# Altium schematic sheet POWER.SchDoc
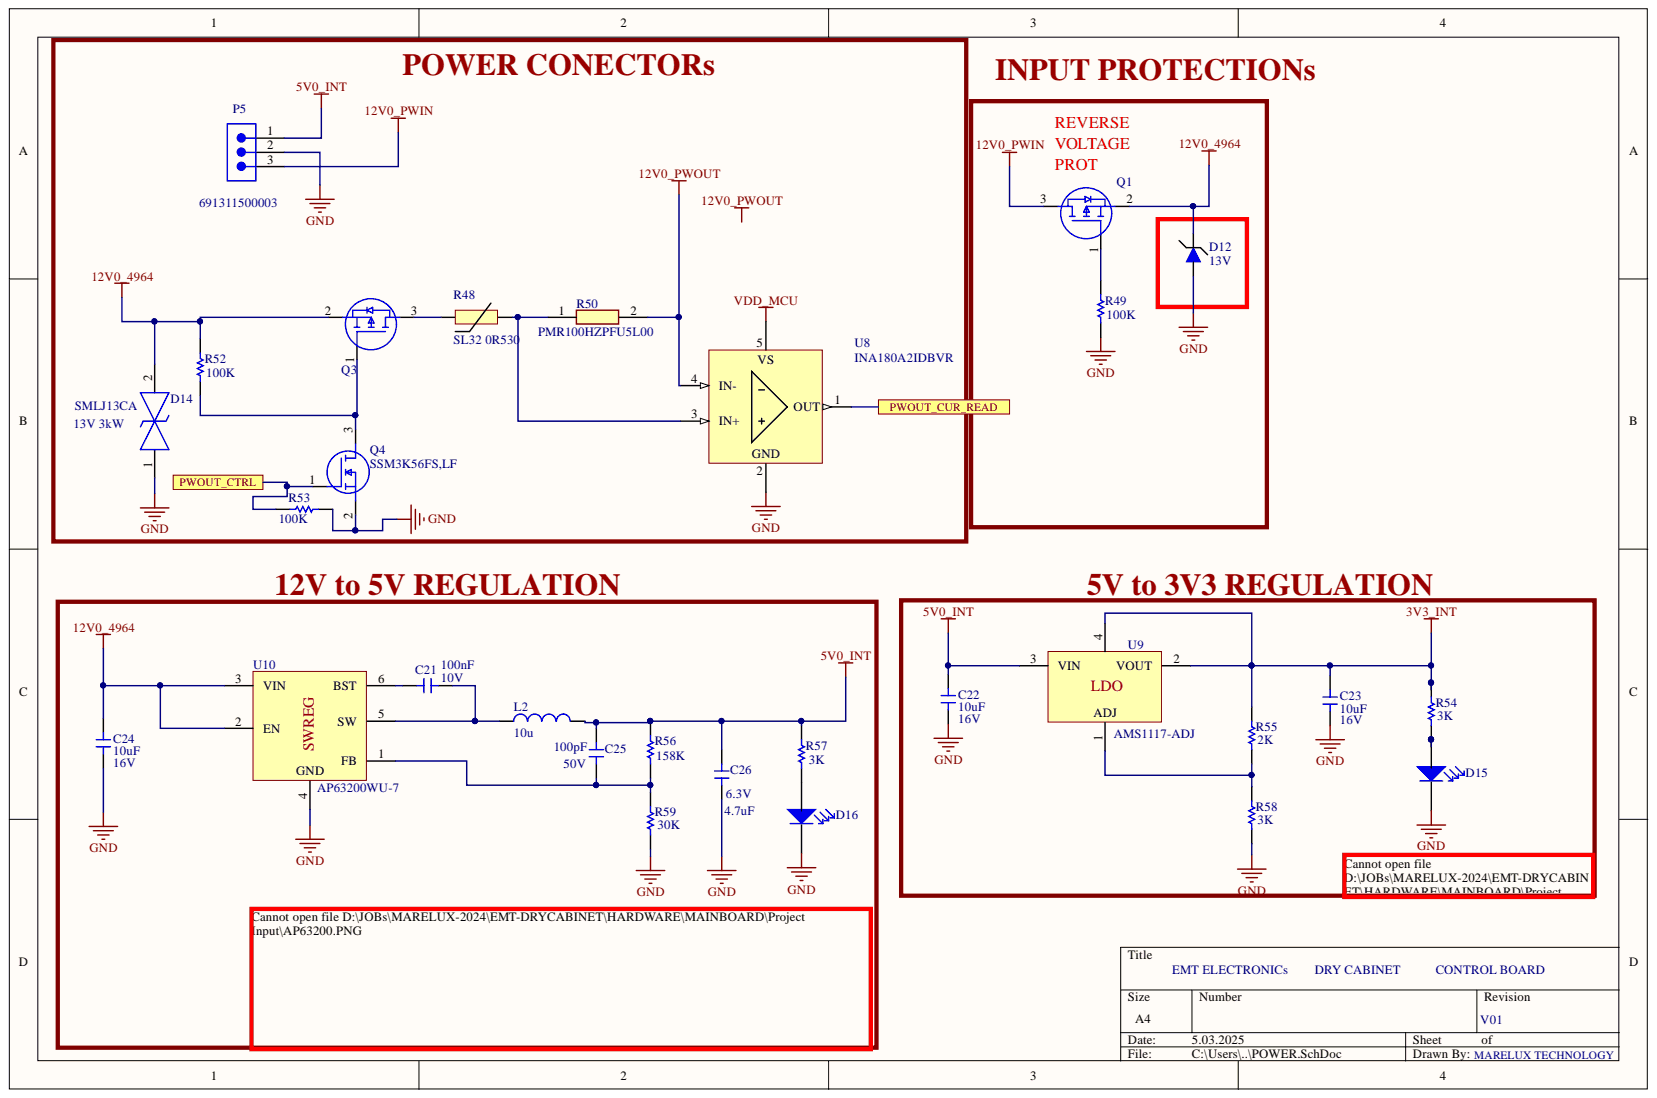
[diagram p0: POWER.SchDoc]

## Parts
C21  value 100nF  Voltage: 10V  [CAP_0402_CER]  (hidden comment: CL05B104KP5NNNC)
C22  value 10uF  Voltage: 16V  [CAP_0805_CER]  (hidden comment: CL21A106KOQNNNE)
C23  value 10uF  Voltage: 16V  [CAP_0805_CER]  (hidden comment: CL21A106KOQNNNE)
C24  value 10uF  Voltage: 16V  [CAP_0805_CER]  (hidden comment: CL21A106KOQNNNE)
C25  value 100pF  Voltage: 50V  [CAP_0402_CER]  (hidden comment: CC0402JRNPO9BN101)
C26  value 4.7uF  Voltage: 6.3V  [CAP_0402_CER]  (hidden comment: CL10A475KP8NNNC)
D12  value 13V  [SOD-123F]  (hidden comment: SMF13A)
D14  SMLJ13CA  value 3kW  [DIONM7959X282N]
D15  HSMM-C170  [LED_0805_SMD]  (value not drawn)
D16  HSMM-C170  [LED_0805_SMD]  (value not drawn)
L2  value 10u  [IND_YAGEO]  (hidden comment: BMRB00060630100MA1)
P5  691311500003  [691311500003]
Q1  SQM110P06-8M9L_GE3  [SQM110P06_MOSFET]  (value not drawn)
Q3  SQM110P06-8M9L_GE3  [SQM110P06_MOSFET]  (value not drawn)
Q4  SSM3K56FS,LF  [1SS423]
R48  SL32 0R530  [SL32 0R530]
R49  value 100K  [RES_0402_SMD]  (hidden comment: RMCF0402JT100K)
R50  PMR100HZPFU5L00  [RESC6432X67N]
R52  value 100K  [RES_0402_SMD]  (hidden comment: RMCF0402JT100K)
R53  value 100K  [RES_0402_SMD]  (hidden comment: RMCF0402JT100K)
R54  value 3K  [RES_0402_SMD]  (hidden comment: RMCF0402FT3K00)
R55  value 2K  [RES_0402_SMD]  (hidden comment: RMCF0402FT2K00)
R56  value 158K  [RES_0402_SMD]  (hidden comment: RMCF0402FT158K)
R57  value 3K  [RES_0402_SMD]  (hidden comment: RMCF0402FT3K00)
R58  value 3K  [RES_0402_SMD]  (hidden comment: RMCF0402FT3K00)
R59  value 30K  [RES_0402_SMD]
U8  INA180A2IDBVR  [SOT95P280X145-5N]
U9  AMS1117-ADJ  Voltage: ADJ  [SOT-223-3]
U10  AP63200WU-7  [TSOT-23-6]

## Ports
PWOUT_CTRL, PWOUT_CUR_READ

## Nets
3V3_INT: C23.2 R54.2 R55.2 U9.2(VOUT) U9.4
5V0_INT: C22.2 C25.2 C26.2 L2.2 P5.1 R56.2 R57.2 U9.3(VIN)
12V0_4964: C24.2 D12.1 D14.2 Q1.2 Q3.2 R52.2 U10.2(EN) U10.3(VIN)
12V0_PWIN: P5.3 Q1.3
12V0_PWOUT: R50.2 U8.4(IN-)
GND: C22.1 C23.1 C24.1 C26.1 D12.2 D14.1 D15.C D16.C P5.2 Q4.2 R49.1 R53.1 R58.1 R59.1 U8.2 U10.4
VDD_MCU: U8.5(VS)
PWOUT_CTRL: Q4.1 R53.2 ; port PWOUT_CTRL
PWOUT_CUR_READ: U8.1(OUT) ; port PWOUT_CUR_READ
NetC21_1: C21.1 U10.6(BST)
NetC21_2: C21.2 L2.1 U10.5(SW)
NetC25_1: C25.1 R56.1 R59.2 U10.1(FB)
NetD15_A: D15.A R54.1
NetD16_A: D16.A R57.1
NetQ1_1: Q1.1 R49.2
NetQ3_1: Q3.1 Q4.3 R52.1
NetQ3_3: Q3.3 R48.1
NetR48_2: R48.2 R50.1 U8.3(IN+)
NetR55_1: R55.1 R58.2 U9.1

## Library data of the parts
C21 C25 C26 = CAP_GEN_CER: Generic; Place: P; Component Kind: Standard; Package: 0402; Library Name: MareLux24Lib.SchLib
  C21: Part Number: CL05B104KP5NNNC
  C25: Part Number: CC0402JRNPO9BN101
  C26: Part Number: CL10A475KP8NNNC
C22-C24 = CAP_GEN_CER: 10uF 0805 Ceramic Capacitor; Place: P; Component Kind: Standard; Part Number: CL21A106KOQNNNE; Library Name: MareLux24Lib.SchLib
D12 = SMF13A: TVS DIODE 200W 14.4V-15.9V SOD-123; Manufacturer: ANBON SEMI; Place: P; Voltage: 14.4V; Component Kind: Standard; Operating Temp.: -55°C ~ 150°C; Package: SOD-123F; Part Number: SMF13A; Power: 200W; Tolerance: N/A; Library Name: MareLux24Lib.SchLib
D14 = SMLJ13CA: 21.5V Clamp 139.4A Ipp Tvs Diode Surface Mount SMC (DO-214AB); Place: P; Tolerance: UD; Voltage: 13V; Component Kind: Standard; ComponentLink1Description: Digikey; ComponentLink1URL: https://www.digikey.com/en/products/detail/bourns-inc/SMLJ13CA/2253492; Operating Temp.: UD; Package: DIONM7959X282N; Part Number: SMLJ13CA; Library Name: MareLux24Lib.SchLib
D15 = LED_GEN: Manufacturer: Broadcom; Place: P; Voltage: 3.3V; Component Kind: Standard; Package: 0805; Part Number: HSMM-C170; Library Name: MareLux24Lib.SchLib
D16 = LED_GEN: Manufacturer: Broadcom; Place: POP; Voltage: 3.3V; Component Kind: Standard; Package: 0805; Part Number: HSMM-C170; Library Name: MareLux24Lib.SchLib
L2 = BMRB00060630100MA1: FIXED IND 10UH 4A 68 MOHM SMD; Manufacturer: PULSE; Current: 4; Place: POP; Tolerance: ±20%; Component Kind: Standard; Operating Temp.: -55°C ~ 125°C; Package: IND_YAGEO; Part Number: BMRB00060630100MA1; Power: N/A; Library Name: MareLux24Lib.SchLib
P5 = 691311500003: WR-TBL Series 311 Open Vertical PCB Header, THT, pitch 5.08mm, 03p; Manufacturer: Wurth Elektronik; Modularity: No; Rated Current: 20A; System: Pluggable Connector; Working Voltage: 300V; Application: Pluggable; Category: Terminal Block; Component Kind: Standard; ComponentLink1Description: Website Link; ComponentLink1URL: https://www.we-online.com/redexpert/article/691311500003?ad; ComponentLink2Description: Datasheet Link; ComponentLink2URL: https://www.we-online.com/redexpert/spec/691311500003?ad; Library Name: Altium_WR-TBL_Pluggable Connector (rev25b).IntLib; Manufacturer Part Number: 691311500003; Match Code: WR-TBL; Max Operating Temperature: 105°C; Min Operating Temperature: -40°C; Mount: Through Hole; Number of Pins: 3; Number of Rows: 1; Orientation: Vertical; Packaging: Box; Pitch: 5.08mm
Q1 Q3 = SQM110P06-8M9L_GE3: P-Channel 60 V 110A (Tc) 230W (Tc) Surface Mount TO-263 (D2PAK); Place: POP; Voltage: 20 V; Component Kind: Standard; Operating Temp.: -55°C ~ 150°C; Package: SQM110P06-8M9L_GE3; Part Number: SQM110P06-8M9L_GE3; Power: 110A; Tolerance: N/A; Value: N/A; Library Name: MareLux24Lib.SchLib
Q4 = SSM3K56FS,LF: MOSFET (N-Channel); Manufacturer_Name: Toshiba; Arrow Part Number: SSM3K56FS,LF; Arrow Price/Stock: https://www.arrow.com/en/products/ssm3k56fslf/toshiba?region=nac; Component Kind: Standard; Datasheet Link: http://toshiba.semicon-storage.com/info/docget.jsp?did=13419&prodName=SSM3K56FS; Height: 0mm; Manufacturer_Part_Number: SSM3K56FS,LF; Mouser Part Number: 757-SSM3K56FSLF; Mouser Price/Stock: https://www.mouser.co.uk/ProductDetail/Toshiba/SSM3K56FSLF?qs=PiFplXvYe5XjAd54EjZm0w%3D%3D
R48 = SL32 0R530: Inrush Current Limiter 500 mOhms ±20% 30 A 1.220" (31.00mm); Manufacturer: Cantherm; Place: POP; Tolerance: 20; Value: 30A; Component Kind: Standard; Operating Temp.: -65°C ~ 150°C; Package: SL32 0R530; Part Number: SL32 0R530; Power: N/A; Voltage: N/A; Library Name: MareLux24Lib.SchLib
R49 R52-R59 = RES_GEN_SMD: Generic Chip Res; Place: P; Component Kind: Standard; Library Name: MareLux24Lib.SchLib
  R49 R52 R53: Part Number: RMCF0402JT100K
  R54 R57 R58: Part Number: RMCF0402FT3K00
  R55: Part Number: RMCF0402FT2K00
  R56: Part Number: RMCF0402FT158K
  R59: Package: RMCF0402JT30K0
R50 = PMR100HZPFU5L00: 5 mOhms ±1% 2W Chip Resistor 2512 (6432 Metric) Automotive AEC-Q200, Current Sense Metal Element; Place: P; Value: 5mOhm; Component Kind: Standard; Package: 2512; Part Number: PMR100HZPFU5L00; Library Name: MareLux24Lib.SchLib
U8 = INA180A2IDBVR: IC CURR SENSE 1 CIRCUIT; Place: P; Value: Current Sense; Voltage: 2.7V ~ 5.5V; Component Kind: Standard; Operating Temp.: -40°C ~ 125°C; Package: SOT23-5; Part Number: INA180A2IDBVR; Power: N/A; Tolerance: N/A; Library Name: MareLux24Lib.SchLib
U9 = AMS1117-ADJ: IC LDO REG ADJ 1A SOT223; Manufacturer: EVVO; Place: P; Component Kind: Standard; Operating Temp.: -40°C ~ 85°C; Package: SOT-223-3; Part Number: AMS1117-ADJ; Power: N/A; Tolerance: N/A; Value: 1A; Library Name: MareLux24Lib.SchLib
U10 = AP63200WU-7: IC REG BUCK ADJ 2A TSOT23-6  3.8V to 32V; Manufacturer: Diodes Incorporated; Operatin Temp.: -40°C ~ 85; Place: POP; Component Kind: Standard; Package: TSOT-23-6; Part Number: AP63200WU-7; Power: N/A; Tolerance: N/A; Value: N/A; Voltage: ADJ; Library Name: MareLux24Lib.SchLib
